# Altium schematic sheet V1_Sheet1.SchDoc(V1_Sheet1)
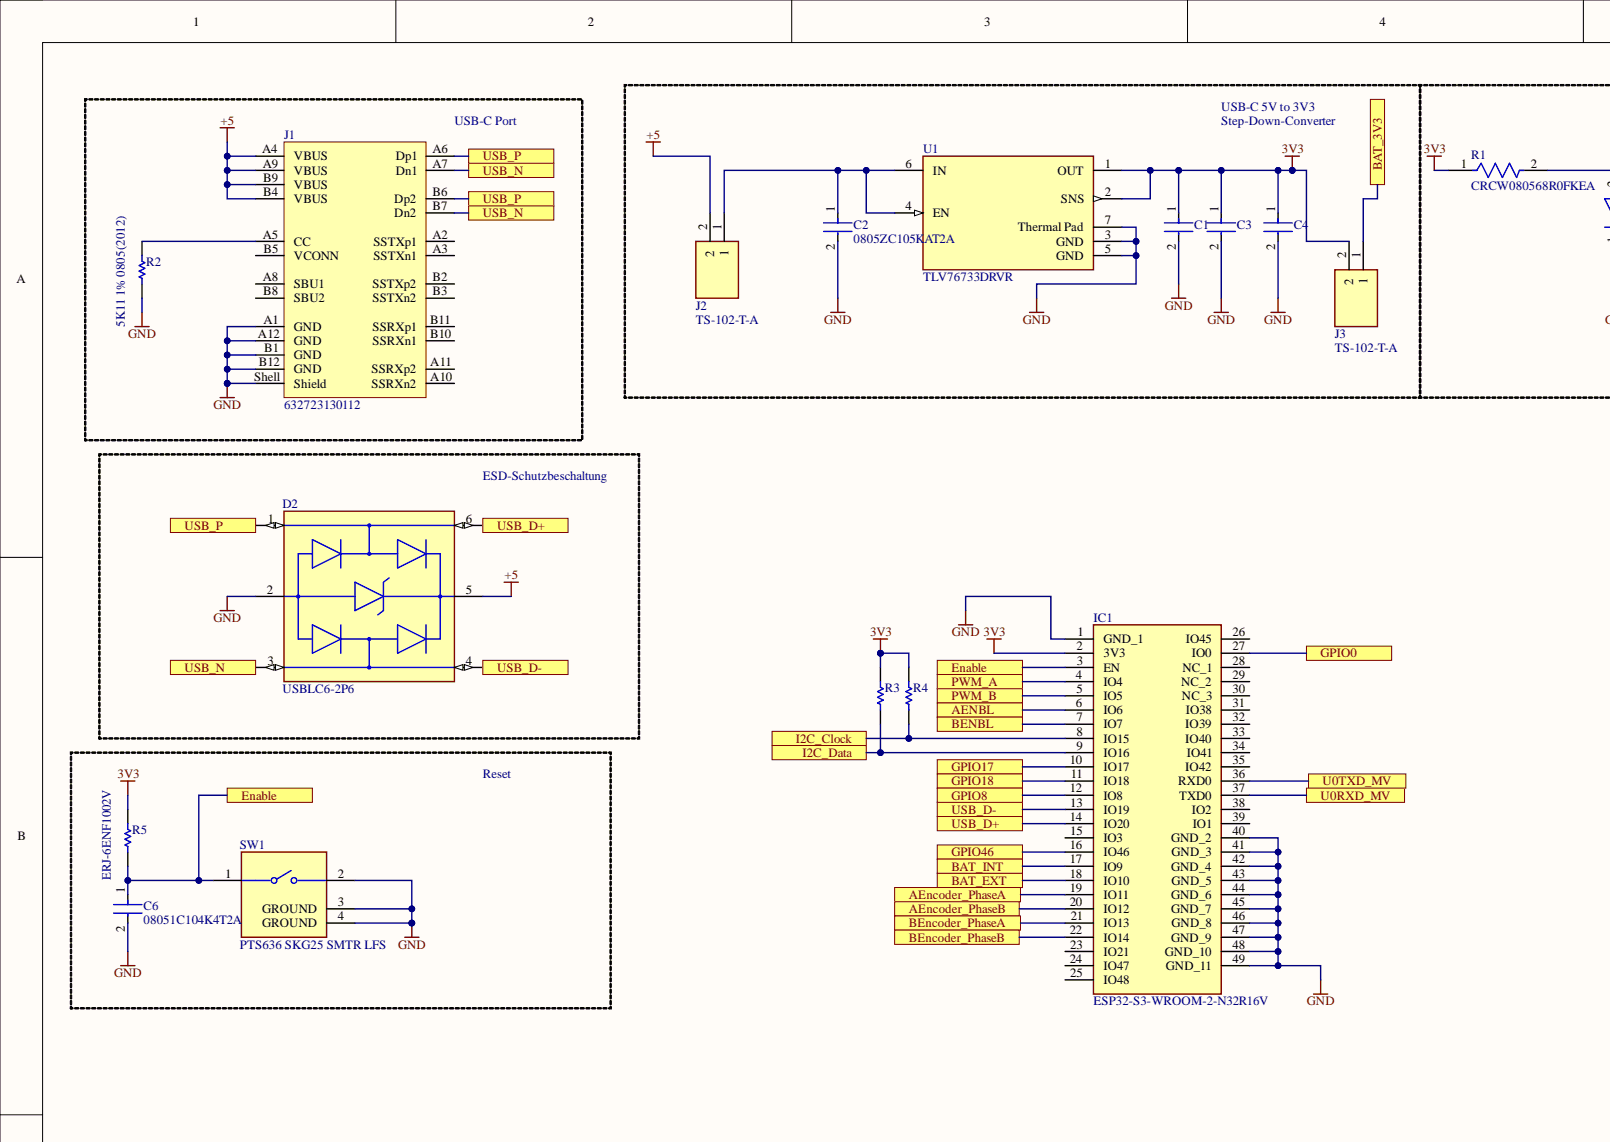
[diagram p0: V1_Sheet1.SchDoc, top left]
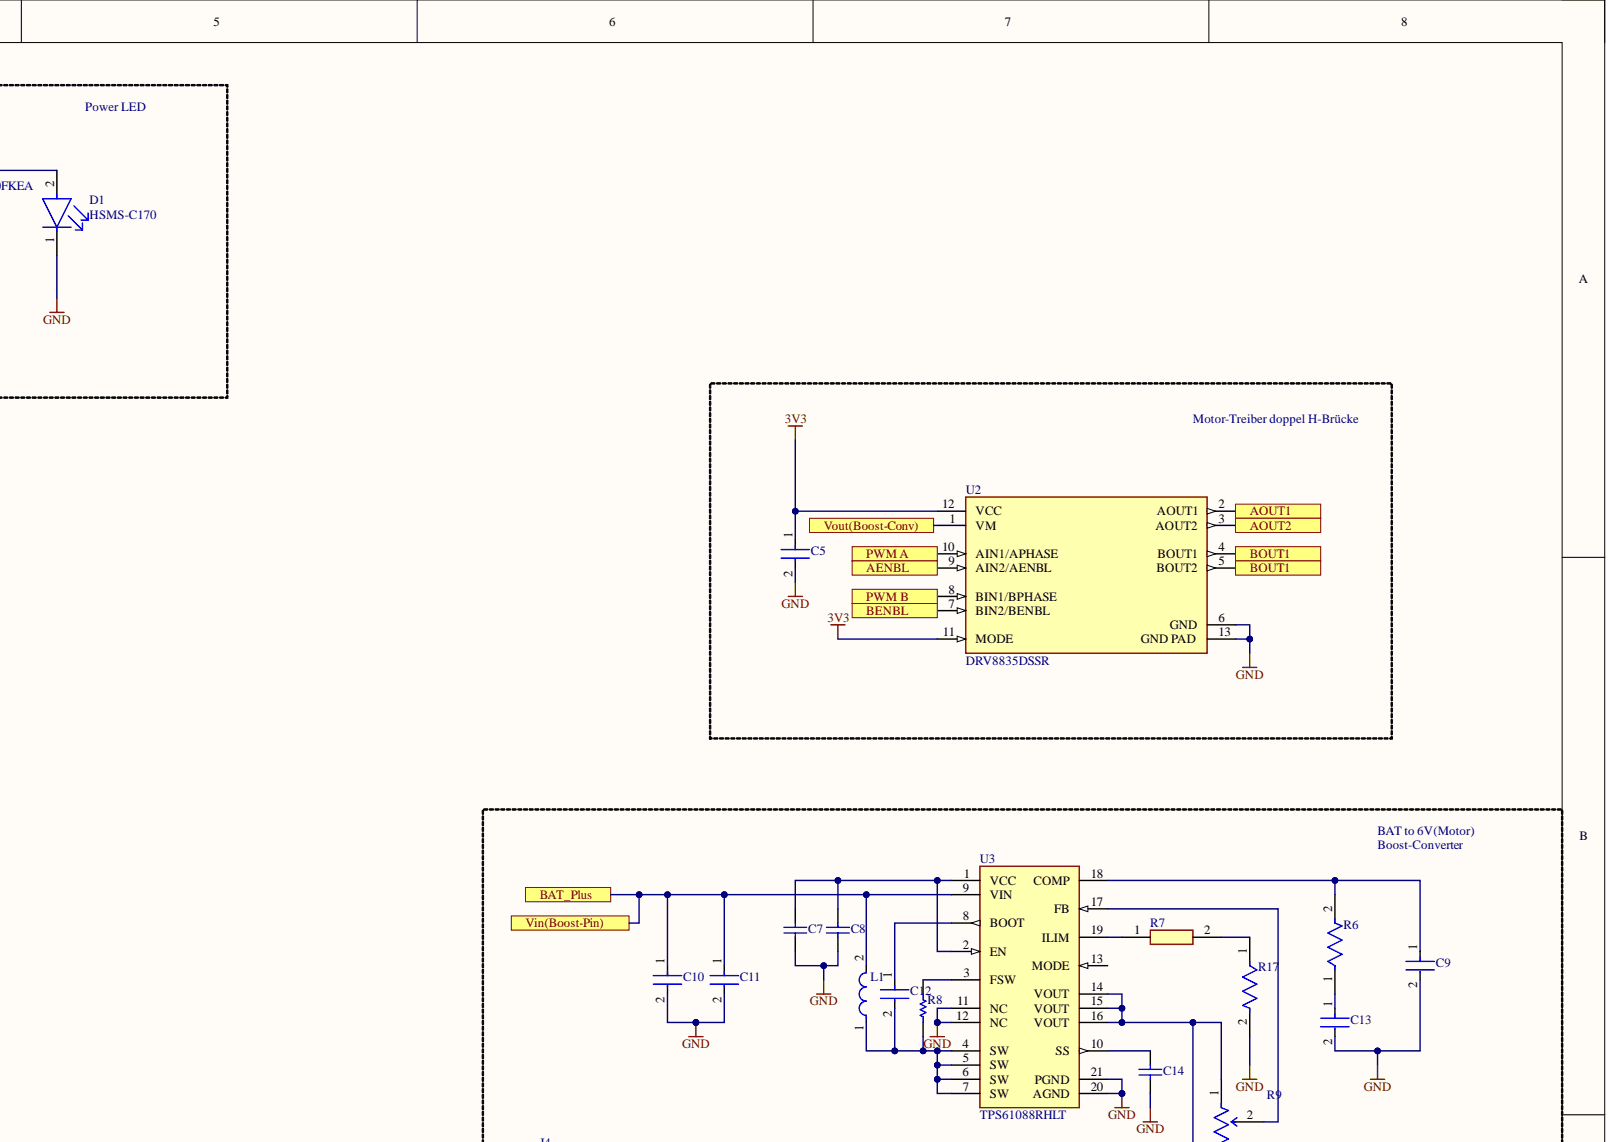
[diagram p1: V1_Sheet1.SchDoc, top right]
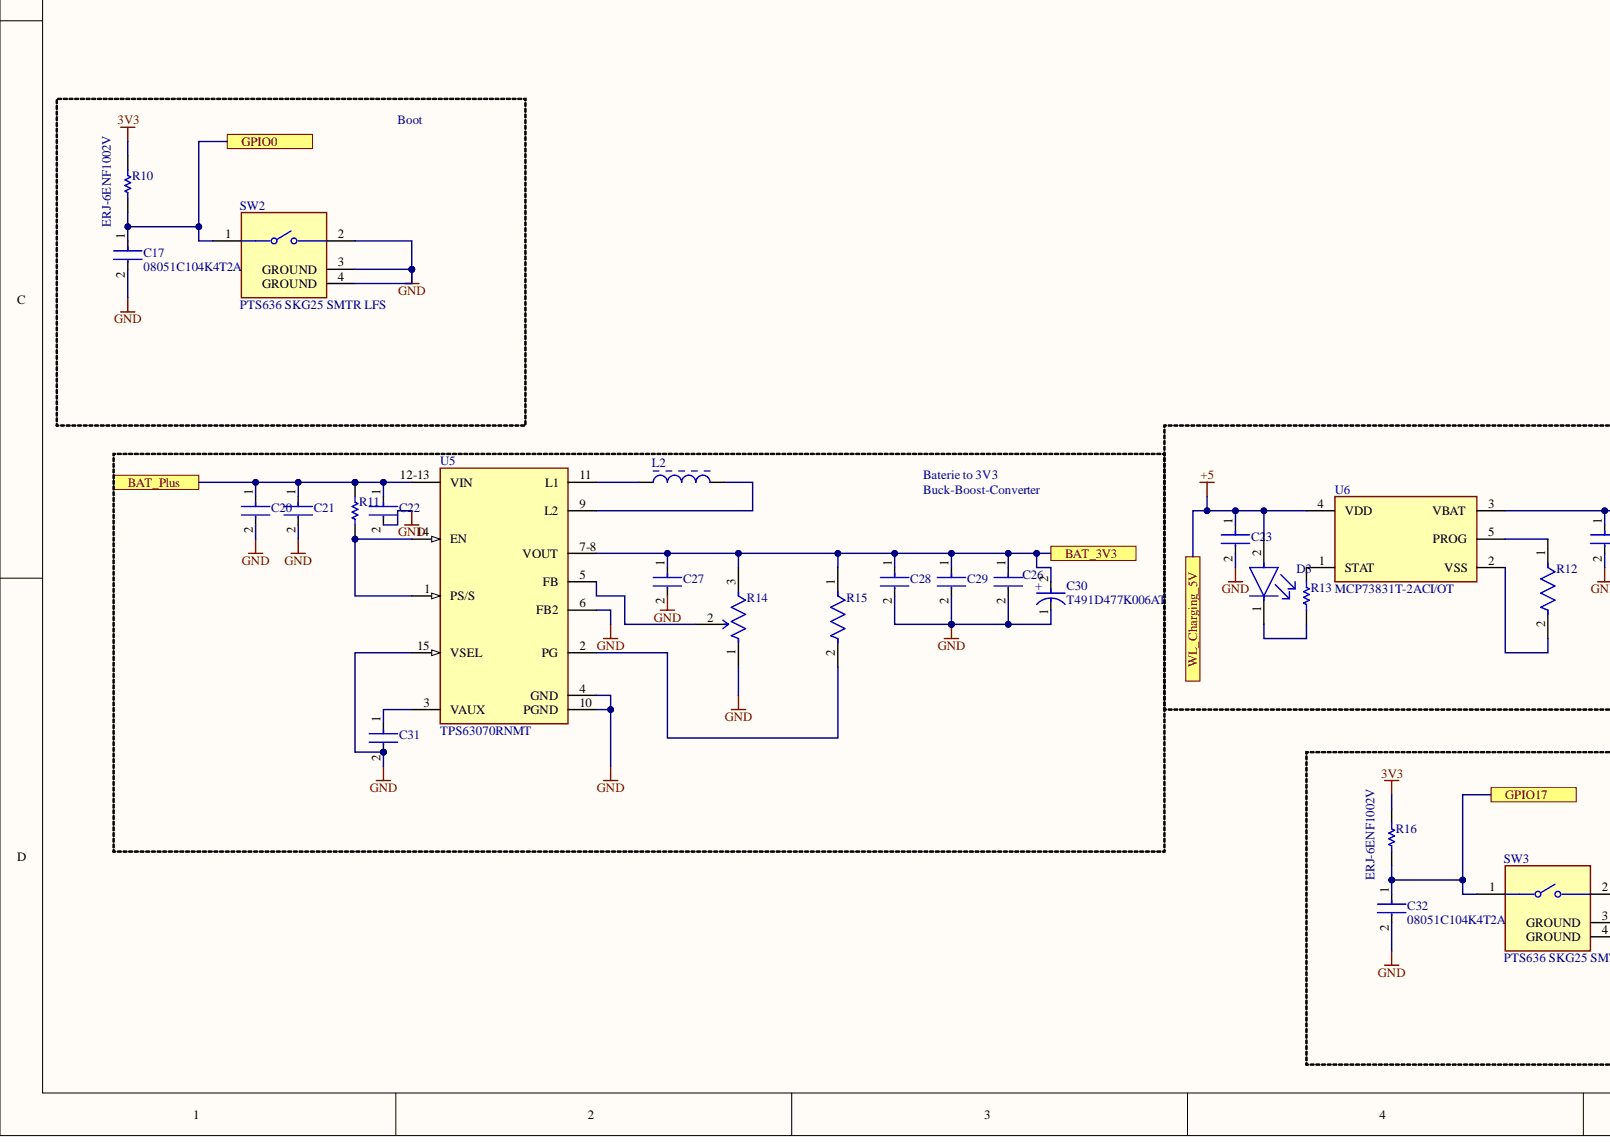
[diagram p2: V1_Sheet1.SchDoc, bottom left]
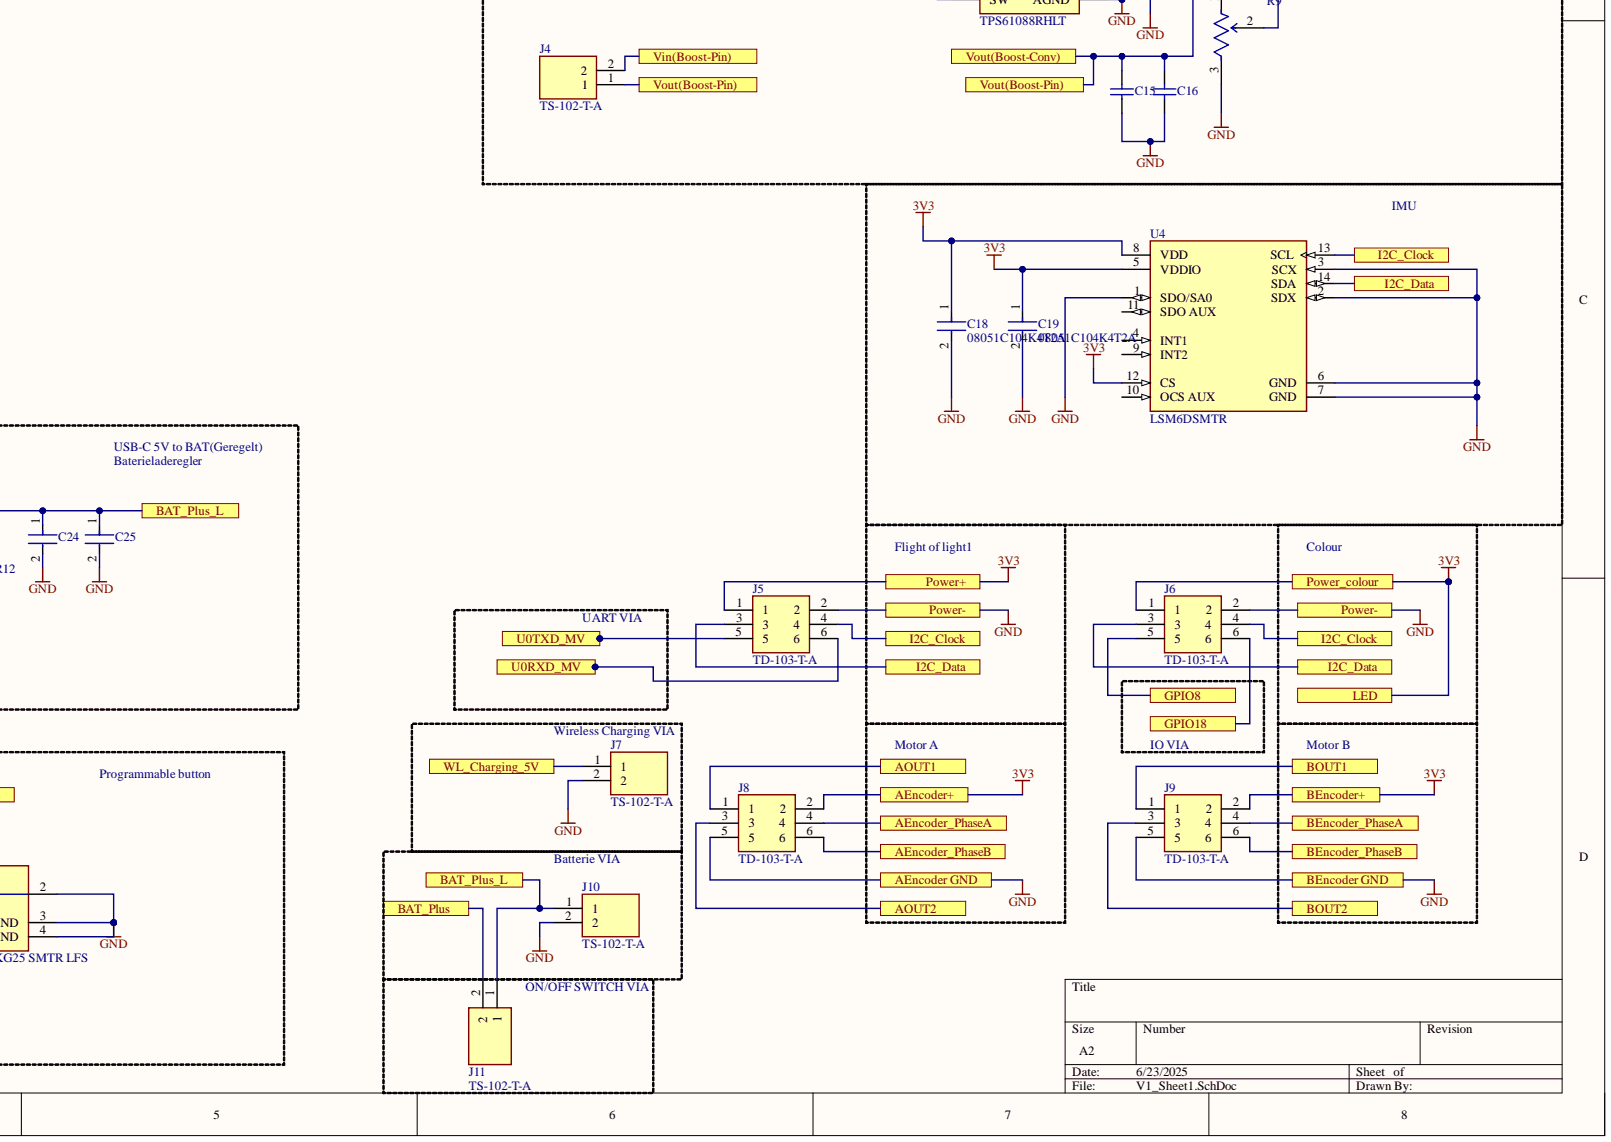
[diagram p3: V1_Sheet1.SchDoc, bottom right]

## Parts
C1  08051C104KAT2A  (value not drawn)
C2  0805ZC105KAT2A
C3  0805ZC105KAT2A
C4  0805ZC105KAT2A
C5  0805ZC105KAT2A
C6  08051C104K4T2A
C7  C0805C105K5RACTU  (value not drawn)
C8  C0805C105K5RACTU  (value not drawn)
C9  C0805C330J1GACTU  (value not drawn)
C10  C0805C476M9PACTU  (value not drawn)
C11  08051C104K4T2A
C12  C0805C104K5RACTU  (value not drawn)
C13  C0805C751J5GACTU  (value not drawn)
C14  0805PC822KAT1A  (value not drawn)
C15  JMK212BJ476MG-T  (value not drawn)
C16  JMK212BJ476MG-T  (value not drawn)
C17  08051C104K4T2A
C18  08051C104K4T2A
C19  08051C104K4T2A
C20  C0805C106K8PACTU  (value not drawn)
C21  C0805C106K8PACTU  (value not drawn)
C22  C0805C106K8PACTU  (value not drawn)
C23  CC0805KKX5R6BB475  (value not drawn)
C24  CC0805KKX5R6BB475  (value not drawn)
C25  CC0805KKX5R6BB475  (value not drawn)
C26  C0805C226M9PACTU  (value not drawn)
C27  C0805C106K8PACTU  (value not drawn)
C28  C0805C226M9PACTU  (value not drawn)
C29  C0805C226M9PACTU  (value not drawn)
C30  T491D477K006AT
C31  08051C104K4T2A
C32  08051C104K4T2A
D1  HSMS-C170
D2  USBLC6-2P6
D3  HSMS-C170
IC1  ESP32-S3-WROOM-2-N32R16V
J1  632723130112
J2  TS-102-T-A
J3  TS-102-T-A
J4  TS-102-T-A
J5  TD-103-T-A
J6  TD-103-T-A
J7  TS-102-T-A
J8  TD-103-T-A
J9  TD-103-T-A
J10  TS-102-T-A
J11  TS-102-T-A
L1  IHLP2525CZER1R0M01  (value not drawn)
L2  SRN3015-1R5Y  (value not drawn)
R1  CRCW080568R0FKEA
R2  5K11 1% 0805(2012)
R3  RC0805FR-0710KL  (value not drawn)
R4  RC0805FR-0710KL  (value not drawn)
R5  ERJ-6ENF1002V
R6  CRCW080532K4FKEA  (value not drawn)
R7  GWCR0805-47KFT5  (value not drawn)
R8  CRCW0805261KFKEA  (value not drawn)
R9  3312J-1-504E  (value not drawn)
R10  ERJ-6ENF1002V
R11  RC0805FR-0710KL  (value not drawn)
R12  CRCW08052K00FKEA  (value not drawn)
R13  CRCW0805470RJNEA  (value not drawn)
R14  3312J-1-504E  (value not drawn)
R15  RC0805FR-07100KL  (value not drawn)
R16  ERJ-6ENF1002V
R17  CRCW0805100KFKEA  (value not drawn)
SW1  PTS636 SKG25 SMTR LFS
SW2  PTS636 SKG25 SMTR LFS
SW3  PTS636 SKG25 SMTR LFS
U1  TLV76733DRVR
U2  DRV8835DSSR
U3  TPS61088RHLT
U4  LSM6DSMTR
U5  TPS63070RNMT
U6  MCP73831T-2ACI/OT

## Ports
AENBL, AEncoder GND, AEncoder+, AEncoder_PhaseA, AEncoder_PhaseB, AOUT1, AOUT2, BAT_3V3, BAT_EXT, BAT_INT, BAT_Plus, BAT_Plus_L, BENBL, BEncoder GND, BEncoder+, BEncoder_PhaseA, BEncoder_PhaseB, BOUT1, BOUT2, Enable, GPIO0, GPIO8, GPIO17, GPIO18, GPIO46, I2C_Clock, I2C_Data, LED, Power+, Power-, Power_colour, PWM A, PWM B, PWM_A, PWM_B, U0RXD_MV, U0TXD_MV, USB_D+, USB_D-, USB_N, USB_P, Vin(Boost-Pin), Vout(Boost-Conv), Vout(Boost-Pin), WL_Charging_5V

## Nets
3V3: C1.1 C3.1 C4.1 C5.1 C18.1 C19.1 IC1.2(3V3) J3.2 J5.1 J6.1 J8.2 J9.2 R1.1 R3.2 R4.2 R5.2 R10.2 R16.2 U1.1(OUT) U1.2(SNS) U2.11(MODE) U2.12(VCC) U4.5(VDDIO) U4.8(VDD) U4.12(CS) ; port AEncoder+, port BEncoder+, port LED, port Power_colour
+5: C23.1 D2.5 D3.2 J1.A4(VBUS) J1.A9(VBUS) J1.B4(VBUS) J1.B9(VBUS) J2.2 J7.1 U6.4(VDD) ; port WL_Charging_5V
AENBL: IC1.6(IO6) U2.9(AIN2/AENBL) ; port AENBL
AEncoder_PhaseA: IC1.19(IO11) J8.4 ; port AEncoder_PhaseA
AEncoder_PhaseB: IC1.20(IO12) J8.6 ; port AEncoder_PhaseB
AOUT1: J8.1 U2.2(AOUT1) ; port AOUT1
AOUT2: J8.3 U2.3(AOUT2) ; port AOUT2
BAT_3V3: C26.1 C27.1 C28.1 C29.1 C30.2 J3.1 R14.3 R15.1 U5.7-8(VOUT) ; port BAT_3V3
BAT_EXT: IC1.18(IO10) ; port BAT_EXT
BAT_INT: IC1.17(IO9) ; port BAT_INT
BAT_Plus: C10.1 C11.1 C20.1 C21.1 C22.1 J4.2 J11.2 L1.2 R11.2 U3.9(VIN) U5.12-13(VIN) ; port BAT_Plus, port Vin(Boost-Pin)
BAT_Plus_L: C24.1 C25.1 J10.1 J11.1 U6.3(VBAT) ; port BAT_Plus_L
BENBL: IC1.7(IO7) U2.7(BIN2/BENBL) ; port BENBL
BEncoder_PhaseA: IC1.21(IO13) J9.4 ; port BEncoder_PhaseA
BEncoder_PhaseB: IC1.22(IO14) J9.6 ; port BEncoder_PhaseB
BOUT1: J9.1 U2.4(BOUT1) U2.5(BOUT2) ; port BOUT1
BOUT2: J9.3 ; port BOUT2
Enable: C6.1 IC1.3(EN) R5.1 SW1.1 ; port Enable
GND: C1.2 C2.2 C3.2 C4.2 C5.2 C6.2 C7.1 C8.1 C9.2 C10.2 C11.2 C13.2 C14.1 C15.1 C16.1 C17.2 C18.2 C19.2 C20.2 C21.2 C22.2 C23.2 C24.2 C25.2 C26.2 C27.2 C28.2 C29.2 C30.1 C31.2 C32.2 D1.1 D2.2 IC1.1(GND_1) IC1.40(GND_2) IC1.41(GND_3) IC1.42(GND_4) IC1.43(GND_5) IC1.44(GND_6) IC1.45(GND_7) IC1.46(GND_8) IC1.47(GND_9) IC1.48(GND_10) IC1.49(GND_11) J1.A1(GND) J1.A12(GND) J1.B1(GND) J1.B12(GND) J1.Shell(Shield) J5.2 J6.2 J7.2 J8.5 J9.5 J10.2 R2.1 R9.3 R14.1 R17.2 SW1.2 SW1.3(GROUND) SW1.4(GROUND) SW2.2 SW2.3(GROUND) SW2.4(GROUND) SW3.2 SW3.3(GROUND) SW3.4(GROUND) U1.3(GND) U1.5(GND) U1.7(Thermal_Pad) U2.6(GND) U2.13(GND_PAD) U3.11(NC) U3.12(NC) U3.20(AGND) U3.21(PGND) U4.1(SDO/SA0) U4.2(SDX) U4.3(SCX) U4.6(GND) U4.7(GND) U5.4(GND) U5.6(FB2) U5.10(PGND) U5.15(VSEL) ; port AEncoder GND, port BEncoder GND
GPIO0: C17.1 IC1.27(IO0) R10.1 SW2.1 ; port GPIO0
GPIO8: IC1.12(IO8) J6.5 ; port GPIO8
GPIO17: C32.1 IC1.10(IO17) R16.1 SW3.1 ; port GPIO17
GPIO18: IC1.11(IO18) J6.6 ; port GPIO18
GPIO46: IC1.16(IO46) ; port GPIO46
I2C_Clock: IC1.8(IO15) J5.4 J6.4 R4.1 U4.13(SCL) ; port I2C_Clock
I2C_Data: IC1.9(IO16) J5.3 J6.3 R3.1 U4.14(SDA) ; port I2C_Data
PWM A: U2.10(AIN1/APHASE) ; port PWM A
PWM B: U2.8(BIN1/BPHASE) ; port PWM B
PWM_A: IC1.4(IO4) ; port PWM_A
PWM_B: IC1.5(IO5) ; port PWM_B
U0RXD_MV: IC1.37(TXD0) J5.6 ; port U0RXD_MV
U0TXD_MV: IC1.36(RXD0) J5.5 ; port U0TXD_MV
USB_N: D2.3 J1.A7(Dn1) J1.B7(Dn2) ; port USB_N
USB_P: D2.1 J1.A6(Dp1) J1.B6(Dp2) ; port USB_P
Vout(Boost-Conv): C15.2 C16.2 J4.1 R9.1 U2.1(VM) U3.14(VOUT) U3.15(VOUT) U3.16(VOUT) ; port Vout(Boost-Conv), port Vout(Boost-Pin)
NetC2_1: C2.1 J2.1 U1.4(EN) U1.6(IN)
NetC7_2: C7.2 C8.2 U3.1(VCC) U3.2(EN)
NetC9_1: C9.1 R6.2 U3.18(COMP)
NetC12_1: C12.1 U3.8(BOOT)
NetC12_2: C12.2 L1.1 R8.1 U3.4(SW) U3.5(SW) U3.6(SW) U3.7(SW)
NetC13_1: C13.1 R6.1
NetC14_2: C14.2 U3.10(SS)
NetC31_1: C31.1 U5.3(VAUX)
NetD1_2: D1.2 R1.2
NetD2_4: D2.4 IC1.13(IO19)
NetD2_6: D2.6 IC1.14(IO20)
NetD3_1: D3.1 R13.1
NetJ1_A5: J1.A5(CC) R2.2
NetL2_1: L2.1 U5.11(L1)
NetL2_2: L2.2 U5.9(L2)
NetR7_1: R7.1 U3.19(ILIM)
NetR7_2: R7.2 R17.1
NetR8_2: R8.2 U3.3(FSW)
NetR9_2: R9.2 U3.17(FB)
NetR11_1: R11.1 U5.1(PS/S) U5.14(EN)
NetR12_1: R12.1 U6.5(PROG)
NetR12_2: R12.2 U6.2(VSS)
NetR13_2: R13.2 U6.1(STAT)
NetR14_2: R14.2 U5.5(FB)
NetR15_2: R15.2 U5.2(PG)

Not connected: IC1 15(IO3) 23(IO21) 24(IO47) 25(IO48) 26(IO45) 28(NC_1) 29(NC_2) 30(NC_3) 31(IO38) 32(IO39) 33(IO40) 34(IO41) 35(IO42) 38(IO2) 39(IO1); J1 A2(SSTXp1) A3(SSTXn1) A8(SBU1) A10(SSRXn2) A11(SSRXp2) B2(SSTXp2) B3(SSTXn2) B5(VCONN) B8(SBU2) B10(SSRXn1) B11(SSRXp1); U3 13(MODE); U4 4(INT1) 9(INT2) 10(OCS_AUX) 11(SDO_AUX)

## Library data of the parts
C1 = CMP-2007-04174-2: General Purpose Ceramic Capacitor, 0805, 100nF, 10%, X7R, 15%, 100V; Capacitance: 100nF; Case Code (Imperial): 0805; Case Code (Metric): 2012; Case/Package: 0805; Component Kind: Standard; Construction: Flat; Contact Plating: Tin; Depth: 1.25mm; Dielectric: X7R; Dielectric Material: Ceramic; Height: 1.4mm; Lead Free: Lead Free; Length: 2.01mm; Library Name: Altium Content Vault; Max Operating Temperature: 125°C; Min Operating Temperature: -55°C; Mount: Surface Mount; Number of Pins: 2; Package Quantity: 2000; Packaging: Tape and Reel; Radiation Hardening: No; REACH SVHC: No SVHC; RoHS Compliant: Yes; Series: -; Termination: SMD/SMT; Thickness: 0.055inch; Tolerance: 10%; Voltage: 100V; Voltage Rating: 100V; Voltage Rating (DC): 100V; Width: 0.049inch
C2-C5 = CMP-1036-04738-3: General Purpose Ceramic Capacitor, 0805, 1uF, 10%, X7R, 15%, 10V; Dissipation Factor: 5%; Insulation Resistance: 100GR; Capacitance: 1uF; Case Code (Imperial): 0805; Case Code (Metric): 2012; Case/Package: 0805; Component Kind: Standard; Construction: Flat; Contact Plating: Tin; Depth: 1.25mm; Dielectric: X7R; Height: 1.4mm; Lead Free: Lead Free; Length: 2.01mm; Library Name: Altium Content Vault; Max Operating Temperature: 125°C; Min Operating Temperature: -55°C; Mount: Surface Mount; Number of Pins: 2; Package Quantity: 1; Packaging: Tape and Reel; Radiation Hardening: No; REACH SVHC: No SVHC; RoHS Compliant: Yes; Series: -; Size: 1.4mm; Termination: SMD/SMT; Thickness: 0.055inch; Tolerance: 10%; Voltage: 10V; Voltage Rating: 10V; Voltage Rating (DC): 10V; Width: 1.25mm
C6 C11 C17-C19 C31 C32 = CMP-2007-04172-2: Automotive Ceramic Capacitor, 0805, 100nF, 10%, X7R, 15%, 100V; Capacitance: 100nF; Case Code (Imperial): 0805; Case Code (Metric): 2012; Case/Package: 0805; Component Kind: Standard; Construction: Flat; Depth: 1.25mm; Dielectric: X7R; Dielectric Material: Ceramic; Height: 1.4mm; Lead Free: Lead Free; Length: 2.01mm; Library Name: Altium Content Vault; Max Operating Temperature: 125°C; Min Operating Temperature: -55°C; Mount: Surface Mount; Number of Pins: 2; Package Quantity: 1; Packaging: Tape and Reel; Radiation Hardening: No; Ratings: AEC-Q200; REACH SVHC: No SVHC; RoHS Compliant: Yes; Series: -; Termination: SMD/SMT; Thickness: 0.055inch; Tolerance: 10%; Voltage: 100V; Voltage Rating: 100V; Voltage Rating (DC): 100V; Width: 0.049inch
C7 C8 = CMP-2007-03236-1: Manufacturer: Kemet; Component Kind: Standard; Library Name: Altium Content Vault; Part Number: C0805C105K5RACTU
C9 = CMP-1036-01444-3: CAP CER 33PF 100V 5% NP0 0805; Manufacturer: KEMET; Material: Ceramic; Temperature Characteristic: C0G; Temperature Coefficient: 30ppm/°C; Tolerance: 0.05; Automotive: No; Capacitance: 33 pF; Category: Cap; Component Kind: Standard; ComponentLink2Description: Datasheet; ComponentLink2URL: https://content.kemet.com/datasheets/KEM_C1003_C0G_SMD.pdf; Device Class L1: Passive Components; Device Class L2: Capacitors; Device Class L3: Ceramic Capacitors; Digikey Description: CAP CER 33PF 100V 5% NP0 0805; Height: 0.88mm; Ipc Land Pattern Name: CAPC200125X78; Lead Free: Yes; Library Name: Altium Content Vault; Manufacturer Part Number: C0805C330J1GACTU; Mouser Description: Cap Ceramic 33pF 100V C0G 5% SMD 0805 125°C Plastic T/R; Octopart: https://octopart.com/c0805c330j1gactu-kemet-2226180; Package: C0805C-DN; RoHS: TRUE; Temperature: -55°C to +125°C; Temperature Range High: +125°C; Temperature Range Low: -55°C; Value: 33 pF; Voltage Rating: 100 V
C10 = CMP-2007-01008-2: CAP CER 47UF 6.3V X5R 0805; Dielectric Material: Ceramic; Dissipation Factor: 5%; Tolerance: 20%; Capacitance: 47uF; Case Code (Imperial): 0805; Case Code (Metric): 2012; Case/Package: 0805; Component Kind: Standard; Construction: Flat; Depth: 1.25mm; Dielectric: X5R; Height: 1.25mm; Length: 2mm; Library Name: Altium Content Vault; Max Operating Temperature: 85°C; Min Operating Temperature: -55°C; Mount: PCB; Number of Pins: 2; Package Quantity: 3000; Packaging: Tape and Reel; Radiation Hardening: No; REACH SVHC: No SVHC; RoHS Compliant: Yes; Series: C0805C; Termination: SMD/SMT; Voltage: 6.3V; Voltage Rating: 6.3V; Voltage Rating (DC): 6.3V; Width: 1.2mm
C12 = CMP-2007-00279-2: CAP CER 0.1UF 50V X7R 0805; Manufacturer: KEMET; Capacitance: 0.10 μF; Material: Ceramic; Temperature Coefficient: 30ppm/°C; Tolerance: 0.1; Value: 0.10 μF; Automotive: No; Category: Cap; Component Kind: Standard; ComponentLink2Description: Datasheet; ComponentLink2URL: https://content.kemet.com/datasheets/KEM_C1003_C0G_SMD.pdf; Device Class L1: Passive Components; Device Class L2: Capacitors; Device Class L3: Ceramic Capacitors; Digikey Description: CAP CER 0.1UF 50V X7R 0805; Height: 0.88mm; Ipc Land Pattern Name: CAPC200125X78; Lead Free: Yes; Library Name: Altium Content Vault; Manufacturer Part Number: C0805C104K5RACTU; Mouser Description: Cap Ceramic 0.1uF 50V X7R 10% SMD 2.0 x 1.2 mm 125 C Paper T/R; Octopart: https://octopart.com/c0805c104k5ractu-kemet-143926; Package: C0805C-DN; RoHS: TRUE; Temperature: -55°C to +125°C; Temperature Characteristic: X7R; Temperature Range High: +125°C; Temperature Range Low: -55°C; Voltage Rating: 50 V
C13 = CMP-2007-00514-2: CAP CER 750PF 50V 5% NP0 0805; Manufacturer: KEMET; Material: Ceramic; Temperature Characteristic: C0G; Temperature Coefficient: 30ppm/°C; Tolerance: 0.05; Automotive: No; Capacitance: 750 pF; Category: Cap; Component Kind: Standard; ComponentLink2Description: Datasheet; ComponentLink2URL: https://content.kemet.com/datasheets/KEM_C1003_C0G_SMD.pdf; Device Class L1: Passive Components; Device Class L2: Capacitors; Device Class L3: Ceramic Capacitors; Digikey Description: CAP CER 750PF 50V 5% NP0 0805; Height: 0.88mm; Ipc Land Pattern Name: CAPC200125X78; Lead Free: Yes; Library Name: Altium Content Vault; Manufacturer Part Number: C0805C751J5GACTU; Mouser Description: Multilayer Ceramic Capacitors MLCC - SMD/SMT 50volts 750pF C0G 5%; Octopart: https://octopart.com/c0805c751j5gactu-kemet-12624845; Package: C0805C-DN; RoHS: TRUE; Temperature: -55°C to +125°C; Temperature Range High: +125°C; Temperature Range Low: -55°C; Value: 750 pF; Voltage Rating: 50 V
C14 = CMP-2007-04816-1: Manufacturer: AVX Interconnect / Elco; Component Kind: Standard; Library Name: Altium Content Vault; Part Number: 0805PC822KAT1A
C15 C16 = CMP-2000-06498-1: High Value Multilayer Ceramic Capacitor, 47 uF, +/-20%, 6.3 V, -55 to 85 degC, 2-Pin SMD (0805), RoHS, Tape and Reel; Manufacturer: Taiyo Yuden; PCN Part Number: X5R,X7RDielectrics23/Jan/2008; Capacitance: 47µF; Catalog Drawings: xMKSeries_0805_1.25; Kits: 587-1797-KIT-ND-KITCAPCERHICAP0603&0805S; Online Catalog: MSeries; Other Names: 587-1779-1; Temperature Coefficient: X5R; Tolerance: ±20%; Voltage - Rated: 6.3V; Applications: GeneralPurpose; Category: Capacitors; Component Kind: Standard; Datasheet URL: http://datasheet.octopart.com/JMK212BJ476MG-T-Taiyo-Yuden-datasheet-62109412.pdf; Datasheet Version: 10/2015; Family: CeramicCapacitors; Features: -; Height - Seated (Max): -; Lead Spacing: -; Lead Style: -; Library Name: Altium Content Vault; Manufacturer URL: http://www.t-yuden.com/; Mounting Technology: SurfaceMount; Mounting Type: SurfaceMount,MLCC; Operating Temperature: -55°C~85°C; Package / Case: 0805(2012Metric); Package Description: 2-Pin SMD, Body 2.2 x 1.45 mm; Package Reference: 0805; Packaging: CutTape(CT); Ratings: -; Series: M; Size / Dimension: 0.079"Lx0.049"W(2.00mmx1.25mm); Standard Package: 1; Thickness (Max): 0.053"(1.35mm)
C20-C22 C27 = CMP-2007-03243-2: CAP CER 10UF 10V X5R 0805; 3DS Part Number: 220-00001; Manufacturer: Kemet; Material: Ceramic; Temperature Coefficient: 15%; Tolerance: 10%; Automotive: No; Capacitance: 10uF; Category: Cap; Component Kind: Standard; ComponentLink2Description: Datasheet; ComponentLink2URL: https://content.kemet.com/datasheets/KEM_C1006_X5R_SMD.pdf; Device Class L1: Passive Components; Device Class L2: Capacitors; Device Class L3: Ceramic Capacitors; Digikey Description: CAP CER 10UF 10V X5R 0805; Height: 1.4mm; Ipc Land Pattern Name: CAPC200125X125; Lead Free: Yes; Library Name: Altium Content Vault; Manufacturer Part Number: C0805C106K8PACTU; Mouser Description: CAP CER 10UF 10V X5R 0805; Octopart: https://octopart.com/c0805c106k8pactu-kemet-98697; Package: C0805C-DG; RoHS: TRUE; Temperature: -55°C to +85°C; Temperature Characteristic: X5R; Temperature Range High: +85°C; Temperature Range Low: -55°C; Value: 10uF; Voltage: 10V; Voltage Rating: 10V
C23-C25 = CMP-03422-001315-1: CAP CER 4.7UF 10V X5R 0805; Manufacturer: Yageo; Material: Ceramic; Tolerance: 0.1; Automotive: No; Capacitance: 4.7 uF; Category: Cap; Component Kind: Standard; ComponentLink2Description: Datasheet; ComponentLink2URL: http://www.yageo.com/documents/recent/UPY-GPHC_Y5V_6.3V-to-50V_8.pdf; Device Class L1: Passive Components; Device Class L2: Capacitors; Device Class L3: Ceramic Capacitors; Digikey Description: CAP CER 4.7UF 10V X5R 0805; Footprint Url: http://www.yageo.com/exep/pages/download/literatures/UPY-C_GEN_24.pdf; Height: 0.95mm; Ipc Land Pattern Name: CAPC200125X85; Lead Free: Yes; Library Name: Altium Content Vault; Manufacturer Part Number: CC0805KKX5R6BB475; Mouser Description: Multilayer Ceramic Capacitors MLCC - SMD/SMT 4.7uF 10V X5R 10%; Octopart: https://octopart.com/cc0805kkx5r6bb475-yageo-42244641; Package: CC0805-0.85; RoHS: TRUE; Temperature: -30°C to +85°C; Temperature Characteristic: X5R; Temperature Range High: +85°C; Temperature Range Low: -30°C; Value: 4.7 uF; Voltage Rating: 10 V
C26 C28 C29 = CMP-2007-01021-2: CAP CER 22UF 6.3V X5R 0805; Dielectric Material: Ceramic; Dissipation Factor: 5%; Tolerance: 20%; Capacitance: 22uF; Case Code (Imperial): 0805; Case Code (Metric): 2012; Case/Package: 0805; Component Kind: Standard; Construction: Flat; Depth: 1.25mm; Dielectric: X5R; Height: 1.25mm; Lead Free: Lead Free; Length: 2mm; Library Name: Altium Content Vault; Max Operating Temperature: 85°C; Min Operating Temperature: -55°C; Mount: Surface Mount; Number of Pins: 2; Package Quantity: 2500; Packaging: Tape and Reel; Radiation Hardening: No; REACH SVHC: No SVHC; RoHS Compliant: Yes; Termination: SMD/SMT; Voltage: 6.3V; Voltage Rating: 6.3V; Voltage Rating (DC): 6.3V; Width: 1.2mm
C30 = CMP-03017-000053-1: CAP TANT 470UF 10% 6.3V 2917; Dielectric Material: Tantalum; Dissipation Factor: 12%; ESR (Equivalent Series Resistance): 400mR; Leakage Current: 19.8uA; Life (Hours): 2000Hour; Polarity: Polar; Ripple Current: 612mA; Capacitance: 470uF; Case Code (Imperial): 2917; Case Code (Metric): 7343; Case/Package: 2917; Component Kind: Standard; Construction: Flat; Depth: 4.3mm; Failure Rate: 0.001%; Features: General Purpose; Height: 3.1mm; Height - Seated (Max): 0.122inch; Lead Free: Lead Free; Length: 7.3mm; Library Name: Altium Content Vault; Max Operating Temperature: 125°C; Min Operating Temperature: -55°C; Mount: Surface Mount; Number of Pins: 2; Package Quantity: 1; Packaging: Tape and Reel; Radiation Hardening: No; REACH SVHC: No SVHC; RoHS Compliant: Yes; Series: T491; Termination: SMD/SMT; Tolerance: 10%; Voltage Rating: 6.3V; Voltage Rating (DC): 6.3V; Weight: 0.023634oz; Width: 0.169inch
D1 D3 = CMP-18399-000018-1: LED RED DIFFUSED CHIP SMD; Dominant Wavelength: 626nm; Forward Current: 20mA; Forward Voltage: 2.1V; Lens Color: White; Luminous Intensity: 10mcd; Number of Elements: 1; Power Dissipation: 52mW; Reverse Voltage: 5V; Shape: Rectangular; Viewing Angle: 170°; Case Code (Imperial): 0805; Case Code (Metric): 2012; Case/Package: 0805; Color: Red; Component Kind: Standard; Depth: 1.25mm; Height: 0.8mm; Illumination Color: Red; Lead Free: Lead Free; Length: 2mm; Lens Style: Diffused; Lens Transparency: Diffused; Library Name: Altium Content Vault; Max Operating Temperature: 85°C; Min Operating Temperature: -40°C; Mount: Surface Mount; Number of LEDs: 1; Number of Pins: 2; Number of Terminals: 2; Package Quantity: 1; Packaging: Tape and Reel; Power Rating: 52mW; Radiation Hardening: No; REACH SVHC: No SVHC; Test Current: 20mA; Wavelength: 626nm; Width: 1.25mm
D2 = CMP-12225-000058-1: TVS DIODE 5.25V 17V SOT666; Breakdown Voltage: 6V; Capacitance: 3.5pF; Direction: Unidirectional; Element Configuration: Dual; ESD Protection: Yes; Leakage Current: 150nA; Number of Bidirectional Channels: 2; Number of Elements: 1; Operating Supply Voltage: 5V; Peak Pulse Current: 5A; Test Current: 1mA; Case/Package: SOT-666; Clamping Voltage: 17V; Component Kind: Standard; Contact Plating: Tin; Depth: 1.3mm; Height: 0.6mm; Lead Free: Lead Free; Length: 1.7mm; Library Name: Altium Content Vault; Max Breakdown Voltage: 6V; Max Operating Temperature: 125°C; Max Reverse Leakage Current: 150nA; Min Breakdown Voltage: 6V; Min Operating Temperature: -40°C; Mount: Surface Mount; Number of Channels: 2; Number of Pins: 6; Package Quantity: 1; Packaging: Tape and Reel; Polarity: Unidirectional; Power Line Protection: Yes; Radiation Hardening: No; REACH SVHC: No SVHC; Reverse Breakdown Voltage: 6V; Reverse Standoff Voltage: 5V; Termination: SMD/SMT; Width: 1.3mm; Working Voltage: 5V
IC1 = ESP32-S3-WROOM-2-N32R16V: Integrated Circuit; Manufacturer_Name: Espressif Systems; Component Kind: Standard; Datasheet Link: https://www.espressif.com/sites/default/files/documentation/esp32-s3-wroom-2_datasheet_en.pdf; Height: 3.25mm; Manufacturer_Part_Number: ESP32-S3-WROOM-2-N32R16V; Mouser Part Number: 356-SP32S3WM2N32R16V; Mouser Price/Stock: https://www.mouser.co.uk/ProductDetail/Espressif-Systems/ESP32-S3-WROOM-2-N32R16V?qs=%252BHhoWzUJg4Kf%2FwmS40wg%252BQ%3D%3D
J1 = CMP-207978-000001-2: WR-COM_USB 3.1_TYPE C_HORIZONTAL; NexarPartId: 99674471; Current Rating: 5A; Gender: Receptacle; Insulation Resistance: 100MΩ; Number of Contacts: 24; USB Standard: USB 3.1; Voltage Rating: 20V; Component Kind: Standard; Contact Material: Gold, Nickel; Height: 3.52mm; Library Name: Altium Content Vault; Max Operating Temperature: 85°C; Min Operating Temperature: -40°C; Number of Pins: 24; Packaging: Tape and Reel; RoHS: Compliant
J2-J4 J7 J10 J11 = TS-102-T-A: Connector; Manufacturer_Name: SAMTEC; Arrow Part Number: TS-102-T-A; Arrow Price/Stock: https://www.arrow.com/en/products/ts-102-t-a/samtec?utm_currency=USD&region=nac; Component Kind: Standard; Manufacturer_Part_Number: TS-102-T-A; Mouser Part Number: 200-TS102TA; Mouser Price/Stock: https://www.mouser.co.uk/ProductDetail/Samtec/TS-102-T-A?qs=0lQeLiL1qyYw%2F4U%252Bgf8JTQ%3D%3D
J5 J6 J8 J9 = TD-103-T-A: Connector; Manufacturer_Name: SAMTEC; Arrow Part Number: TD-103-T-A; Arrow Price/Stock: https://www.arrow.com/en/products/td-103-t-a/samtec; Component Kind: Standard; Manufacturer_Part_Number: TD-103-T-A; Mouser Part Number: 200-TD103TA; Mouser Price/Stock: https://www.mouser.com/Search/Refine.aspx?Keyword=200-TD103TA
L1 = CMP-02424-000599-1: Commercial Inductors, High Saturation Series 1uH 11A 10mΩ 20%; Core Material: Metal; DC Resistance (DCR): 10mR; Saturation Current: 22A; Self Resonant Frequency: 5MHz; Shielding: Shielded; Test Frequency: 100kHz; Case/Package: 2525; Component Kind: Standard; Contact Plating: Tin; Current Rating: 11A; Depth: 6.72mm; Height: 3mm; Inductance: 1uH; Inductance Tolerance: 20%; Lead Free: Lead Free; Length: 7.24mm; Library Name: Altium Content Vault; Max DC Current: 11A; Max Operating Temperature: 125°C; Military Standard: Not; Min Operating Temperature: -55°C; Mount: Surface Mount; Number of Pins: 2; Package Quantity: 2000; Packaging: Tape and Reel; Radiation Hardening: No; RMS Current (Irms): 11A; Termination: SMD/SMT; Tolerance: 20%; Width: 6.47mm
L2 = CMP-0007-00008-1: Semi-shielded Power Inductor, 1.5 uH, +/- 30%, 2.1 A, -40 to 125 degC, 3 x 3 x 1.5 mm SMD, RoHS and Halogen Free, Tape and Reel; Manufacturer: Bourns; DCR Max: 0.066 Ohm; Inductance: 1.5 uH; Inductance tolerance: +/- 30%; Isat: 2 A; Rated current: 2.1 A; Resistance to Solder Heat: +260 degC for 10 sec.; SRF Min: 130 MHz; Component Kind: Standard; ComponentLink1Description: Manufacturer URL; ComponentLink1URL: http://www.bourns.com/; ComponentLink2Description: Datasheet; ComponentLink2URL: http://www.bourns.com/data/global/pdfs/SRN3015.pdf; ComponentLink3Description: Package Specification; ComponentLink3URL: http://www.bourns.com/data/global/pdfs/SRN3015.pdf; DatasheetVersion: Aug-11; Library Name: Altium Content Vault; Mounting Technology: Surface Mount; Operating Temperature: -40 to 125 degC; PackageDescription: 2-Pin SMD, Body 3 x 3 mm, Height 1.5 mm; PackageReference: SRN3015; Packing: Tape and Reel; PartNumber: SRN3015-1R5Y; RoHS: TRUE
R1 = CMP-1013-00378-3: RES Thick Film, 68Ω, 1%, 0.125W, 100ppm/°C, 0805; Max Power Dissipation: 125mW; Resistance: 68R; Voltage Rating: 150V; Case Code (Imperial): 0805; Case Code (Metric): 2012; Case/Package: 0805; Component Kind: Standard; Composition: Thick Film; Contact Plating: Tin; Depth: 1.25mm; Features: Automotive AEC-Q200; Height: 0.45mm; Lead Free: Lead Free; Length: 2mm; Library Name: Altium Content Vault; Max Operating Temperature: 155°C; Military Standard: Not; Min Operating Temperature: -55°C; Mount: Surface Mount; Number of Terminations: 2; Package Quantity: 5000; Packaging: Tape and Reel; Power Rating: 125mW; Radiation Hardening: No; Temperature Coefficient: 100ppm/°C; Termination: SMD/SMT; Tolerance: 1%; Voltage Rating (DC): 150V; Weight: 0.000194oz; Width: 0.049inch
R2 = CMP-1013-00591-1: 5K11 0.125W 1% 0805 (2012 Metric)  SMD; Case-EIA: 0805; Case-Metric: 2012; Value: 5110.00 Ohm; Component Kind: Standard; Library Name: Altium Content Vault; Power: 0.125 W; Technology: SMT; Tolerance: 1 %
R3 R4 R11 = CMP-1659-00013-4: Chip Resistor, 10 KOhm, +/- 1%, 0.125 W, -55 to 155 degC, 0805 (2012 Metric), RoHS, Tape and Reel; Manufacturer: Yageo; Voltage Rating: 150 V; Component Kind: Standard; ComponentLink1Description: Manufacturer URL; ComponentLink1URL: http://www.yageo.com/; ComponentLink2Description: Datasheet; ComponentLink2URL: http://www.yageo.com/documents/recent/PYu-RC_Group_51_RoHS_L_04.pdf; DatasheetVersion: Rev. 4, 02/2016; Height: 0.5 mm; Length: 2 mm; Library Name: Altium Content Vault; Max Operating Temperature: 155 degC; Min Operating Temperature: -55 degC; Mount: Surface Mount; Mounting Technology: Surface Mount; Package Quantity: 5000; PackageDescription: 2-Pin Surface Mounted Device, Body 2 x 1.25 mm; PackageReference: 0805; Packaging: Tape and Reel; Pins: 2; REACH SVHC: No SVHC; RoHSCompliant: true
R5 R10 R16 = CMP-2001-04376-1: Manufacturer: Panasonic; Component Kind: Standard; Library Name: Altium Content Vault; Part Number: ERJ-6ENF1002V
R6 = CMP-2001-03818-2: RES Thick Film, 32.4kΩ, 1%, 0.125W, 100ppm/°C, 0805; Max Power Dissipation: 125mW; Resistance: 32.4kR; Voltage Rating: 150V; Case Code (Imperial): 0805; Case Code (Metric): 2012; Case/Package: 0805; Component Kind: Standard; Composition: Thick Film; Contact Plating: Tin; Depth: 1.25mm; Features: Automotive AEC-Q200; Height: 0.02inch; Lead Free: Lead Free; Length: 2mm; Library Name: Altium Content Vault; Max Operating Temperature: 155°C; Military Standard: Not; Min Operating Temperature: -55°C; Number of Pins: 2; Number of Terminations: 2; Package Quantity: 10; Packaging: Tape and Reel; Power Rating: 125mW; Radiation Hardening: No; Temperature Coefficient: 100ppm/°C; Termination: SMD/SMT; Tolerance: 1%; Voltage Rating (DC): 150V; Weight: 0.000194oz; Width: 1.25mm
R7 = GWCR0805-47KFT5: Resistor; Manufacturer_Name: TT Electronics; Component Kind: Standard; Datasheet Link: https://www.mouser.com/datasheet/2/414/TTRB_S_A0010064762_1-2565540.pdf; Height: 0.65mm; Manufacturer_Part_Number: GWCR0805-47KFT5; Mouser Part Number: 756-GWCR0805-47KFT5; Mouser Price/Stock: https://www.mouser.co.uk/ProductDetail/Welwyn-Components-TT-Electronics/GWCR0805-47KFT5?qs=EU6FO9ffTwddHWxqz2Mtpg%3D%3D
R8 = CMP-2001-03725-1: Manufacturer: Vishay Dale; Component Kind: Standard; Library Name: Altium Content Vault; Part Number: CRCW0805261KFKEA
R9 R14 = CMP-07234-000022-1: TRIMMER 500K OHM 0.1W J LEAD TOP; Insulation Resistance: 500MR; Max Power Dissipation: 100mW; Number of Turns: 1; Resistance: 500kR; Temperature Coefficient: 100ppm/°C; Tolerance: 20%; Voltage Rating: 500V; Component Kind: Standard; Composition: Cermet; Depth: 2.8mm; Height: 0.067inch; Length: 2.5mm; Library Name: Altium Content Vault; Max Operating Temperature: 125°C; Min Operating Temperature: -55°C; Mount: Surface Mount; Package Quantity: 1; Packaging: Tape and Reel; Power Rating: 100mW; REACH SVHC: No SVHC; Termination: J-Hook; Voltage Rating (DC): 500V; Weight: 0.0321g; Width: 2.5mm
R12 = CMP-2001-03755-2: RES Thick Film, 2kΩ, 1%, 0.125W, 100ppm/°C, 0805; Max Power Dissipation: 125mW; Resistance: 2kR; Voltage Rating: 150V; Case Code (Imperial): 0805; Case Code (Metric): 2012; Case/Package: 0805; Component Kind: Standard; Composition: Thick Film; Contact Plating: Tin; Depth: 1.25mm; Features: Automotive AEC-Q200; Height: 0.02inch; Lead Free: Lead Free; Length: 2mm; Library Name: Altium Content Vault; Max Operating Temperature: 155°C; Military Standard: Not; Min Operating Temperature: -55°C; Mount: Surface Mount; Number of Pins: 2; Number of Terminals: 2; Number of Terminations: 2; Package Quantity: 10; Packaging: Tape and Reel; Power Rating: 125mW; Radiation Hardening: No; Temperature Coefficient: 100ppm/°C; Termination: SMD/SMT; Tolerance: 1%; Voltage Rating (DC): 150V; Weight: 0.000194oz; Width: 1.25mm; Working Voltage: 150V
R13 = CMP-2001-03933-1: Manufacturer: Vishay Dale; Component Kind: Standard; Library Name: Altium Content Vault; Part Number: CRCW0805470RJNEA
R15 = CMP-2100-03668-5: RES 100K OHM 1% 1/8W 0805; Max Power Dissipation: 125mW; Resistance: 100kR; Temperature Coefficient: 100ppm/°C; Voltage Rating: 150V; Case Code (Imperial): 0805; Case Code (Metric): 2012; Case/Package: 0805; Component Kind: Standard; Construction: Rectangular; Depth: 1.25mm; Features: Moisture Resistant; Height: 0.024inch; Lead Free: Lead Free; Lead Pitch: 0.4mm; Length: 0.079inch; Library Name: Altium Content Vault; Max Operating Temperature: 155°C; Military Standard: Not; Min Operating Temperature: -55°C; Number of Pins: 2; Number of Terminations: 2; Package Quantity: 1; Packaging: Tape and Reel; Power Rating: 125mW; Resistance Tolerance: 1%; Resistor Type: Thick Film; RoHS Compliant: Yes; Series: RC; Size Code: 0805; Technology: THICK FILM; Termination: SMD/SMT; Tolerance: 1%; Voltage Rating (DC): 150V; Width: 0.049inch
R17 = CMP-2001-04899-2: RES Thick Film, 100kΩ, 1%, 0.125W, 100ppm/°C, 0805; Power Rating: 125mW; Resistance: 100kR; Voltage Rating: 150V; Case Code (Imperial): 0805; Case Code (Metric): 2012; Case/Package: 0805; Component Kind: Standard; Composition: Thick Film; Contact Plating: Tin; Depth: 1.25mm; Height: 0.45mm; Lead Free: Lead Free; Length: 2mm; Library Name: Altium Content Vault; Max Operating Temperature: 155°C; Military Standard: Not; Min Operating Temperature: -55°C; Mount: Surface Mount; Number of Pins: 2; Package Quantity: 5000; Packaging: Tape and Reel; Radiation Hardening: No; Temperature Coefficient: 100ppm/°C; Termination: SMD/SMT; Tolerance: 1%; Voltage Rating (DC): 150V; Weight: 0.000194oz; Width: 1.25mm
SW1-SW3 = CMP-10040-000025-1: TACT 6.0 X 3.5, 2.5 MM H, 250GF,; Component Kind: Standard; Library Name: Altium Content Vault
U1 = CMP-04917-000203-1: VOLTAGE COMPARATOR; Component Kind: Standard; Library Name: Altium Content Vault
U2 = CMP-04915-000062-1: IC MTR DRVR BIPOLAR 2-7V 12WSON; Collector Emitter Voltage (VCEO): 11V; Drain to Source Resistance: 305mR; Interface: Parallel; Logic Function: AND GATE; Max Collector Current: 1.5A; Max Supply Voltage: 7V; Min Supply Voltage: 2V; Motor Type: Stepper; Number of Outputs: 2; Switching Frequency: 250kHz; Case/Package: SON; Component Kind: Standard; Height: 0.75mm; Lead Free: Lead Free; Length: 3.1mm; Library Name: Altium Content Vault; Max Operating Temperature: 85°C; Min Operating Temperature: -40°C; Mount: Surface Mount; Number of Pins: 12; Operating Supply Current: 1.5A; Output Current: 1.5A; Output Voltage: 11V; Package Quantity: 3000; Packaging: Tape and Reel; Radiation Hardening: No; REACH SVHC: No SVHC; RoHS Compliant: Yes; Series: DRV8835; Width: 2.1mm
U3 = CMP-04918-000117-1: IC REG BOOST ADJ 10A 20VQFN; Manufacturer: Texas Instruments; Max Duty Cycle: 90%; Max Junction Temp: +125°C; Max Supply Voltage: 12V; Min Supply Voltage: 2.7V; Nominal Supply Current: 1-110uA; Number Of Outputs: 1; Output Type: AdjustableProgrammable; Output Voltage: 4.5-12.6V; Switching Frequency: 200-2200kHz; Automotive: No; Category: IC; Component Kind: Standard; ComponentLink2Description: Datasheet; ComponentLink2URL: http://www.ti.com/lit/ds/symlink/tps61088.pdf; Device Class L1: Integrated Circuits (ICs); Device Class L2: Power Management ICs; Device Class L3: Voltage Regulators - Switching; Digikey Description: IC REG BOOST ADJ 10A 20VQFN; Height: 1mm; Lead Free: Yes; Library Name: Altium Content Vault; Manufacturer Part Number: TPS61088RHLT; Octopart: https://octopart.com/tps61088rhlt-texas+instruments-57155059; Output Current: 10A; Package: RHL0020A; RoHS: TRUE; Switching Topology: Boost; Temperature: -40°C to +85°C; Temperature Range High: +85°C; Temperature Range Low: -40°C
U4 = CMP-12177-000008-1: IMU ACCEL/GYRO I2C/SPI 14LGA; Manufacturer: STMicroelectronics; Accel Range: 2/4/8/16g; Gyro Range: 125/250/500/1000/2000°/s; Max Supply Voltage: 3.6V; Min Supply Voltage: 1.62V; Operating Supply Current: 0.29-0.65mA; Resolution: 16bits; Sensor Output: I2C,SPI; Sensor Type: Temperature,Accelerometer,Gyroscope; Automotive: No; Category: IC; Component Kind: Standard; ComponentLink2Description: Datasheet; ComponentLink2URL: https://www.st.com/resource/en/datasheet/lsm6dsm.pdf; Device Class L1: Sensors; Device Class L2: Motion Sensors; Device Class L3: Inertial Measurement Units (IMUs); Digikey Description: IMU ACCEL/GYRO I2C/SPI 14LGA; Footprint Url: https://www.st.com/content/ccc/resource/technical/document/technical_note/73/17/9c/16/dd/96/4a/01/CD00134799.pdf/files/CD00134799.pdf/jcr:content/translations/en.CD00134799.pdf; Height: 0.86mm; Lead Free: Yes; Library Name: Altium Content Vault; Manufacturer Part Number: LSM6DSMTR; Mouser Description: LSM6DSM Series 3.6 V I2C/SPI 3D Accelerometer and 3D Gyroscope - LGA-14; Octopart: https://octopart.com/lsm6dsmtr-stmicroelectronics-66358194; Package: LGA-14L-DM00249496; RoHS: TRUE; Temperature: -40°C to +85°C; Temperature Range High: +85°C; Temperature Range Low: -40°C
U5 = CMP-04918-000001-1: IC REG BCK BST ADJ 2A 15VQFN; Manufacturer: Texas Instruments; Max Duty Cycle: 100%; Max Junction Temp: +125°C; Max Supply Voltage: 16V; Min Supply Voltage: 2V; Nominal Supply Current: 5-54uA; Number Of Outputs: 1; Output Type: AdjustableProgrammable; Output Voltage: 2.5-9V; Standoff Height: 0mm; Switching Frequency: 2400kHz; Switching Topology: Buck-Boost; Automotive: No; Category: IC; Component Kind: Standard; ComponentLink2Description: Datasheet; ComponentLink2URL: http://www.ti.com/lit/ds/symlink/tps63070.pdf; Device Class L1: Integrated Circuits (ICs); Device Class L2: Power Management ICs; Device Class L3: Voltage Regulators - Switching; Digikey Description: IC REG BCK BST ADJ 2A 15VQFN; Height: 1mm; Lead Free: Yes; Library Name: Altium Content Vault; Manufacturer Part Number: TPS63070RNMT; Octopart: https://octopart.com/tps63070rnmt-texas+instruments-75117739; Output Current: 2A; Package: RNM0015A; RoHS: TRUE; Temperature: -40°C to +125°C; Temperature Range High: +125°C; Temperature Range Low: -40°C
U6 = CMP-0133-00143-2: IC CONTROLLR LI-ION 4.2V SOT23-5; Drain to Source Resistance: 350mR; Max Charge Current: 500mA; Max Input Voltage: 6V; Max Output Voltage: 4.5V; Max Power Dissipation: 1.54W; Min Output Voltage: 4.168V; Min Supply Voltage: 3.75V; Number of Cells: 1; Voltage Rating: 4.534V; Case/Package: SOT-23; Component Kind: Standard; Contact Plating: Tin; Height: 0.051inch; Lead Free: Lead Free; Length: 0.122inch; Library Name: Altium Content Vault; Max Operating Temperature: 85°C; Max Supply Voltage: 6V; Min Operating Temperature: -40°C; Mount: Surface Mount; Number of Channels: 1; Number of Outputs: 1; Number of Pins: 5; Output Current: 500mA; Output Voltage: 4.2V; Packaging: Tape and Reel; Radiation Hardening: No; REACH SVHC: No SVHC; Termination: SMD/SMT; Weight: 0.00709oz; Width: 0.071inch
